# Altium schematic sheet advertising_board_v2.0.SchDoc
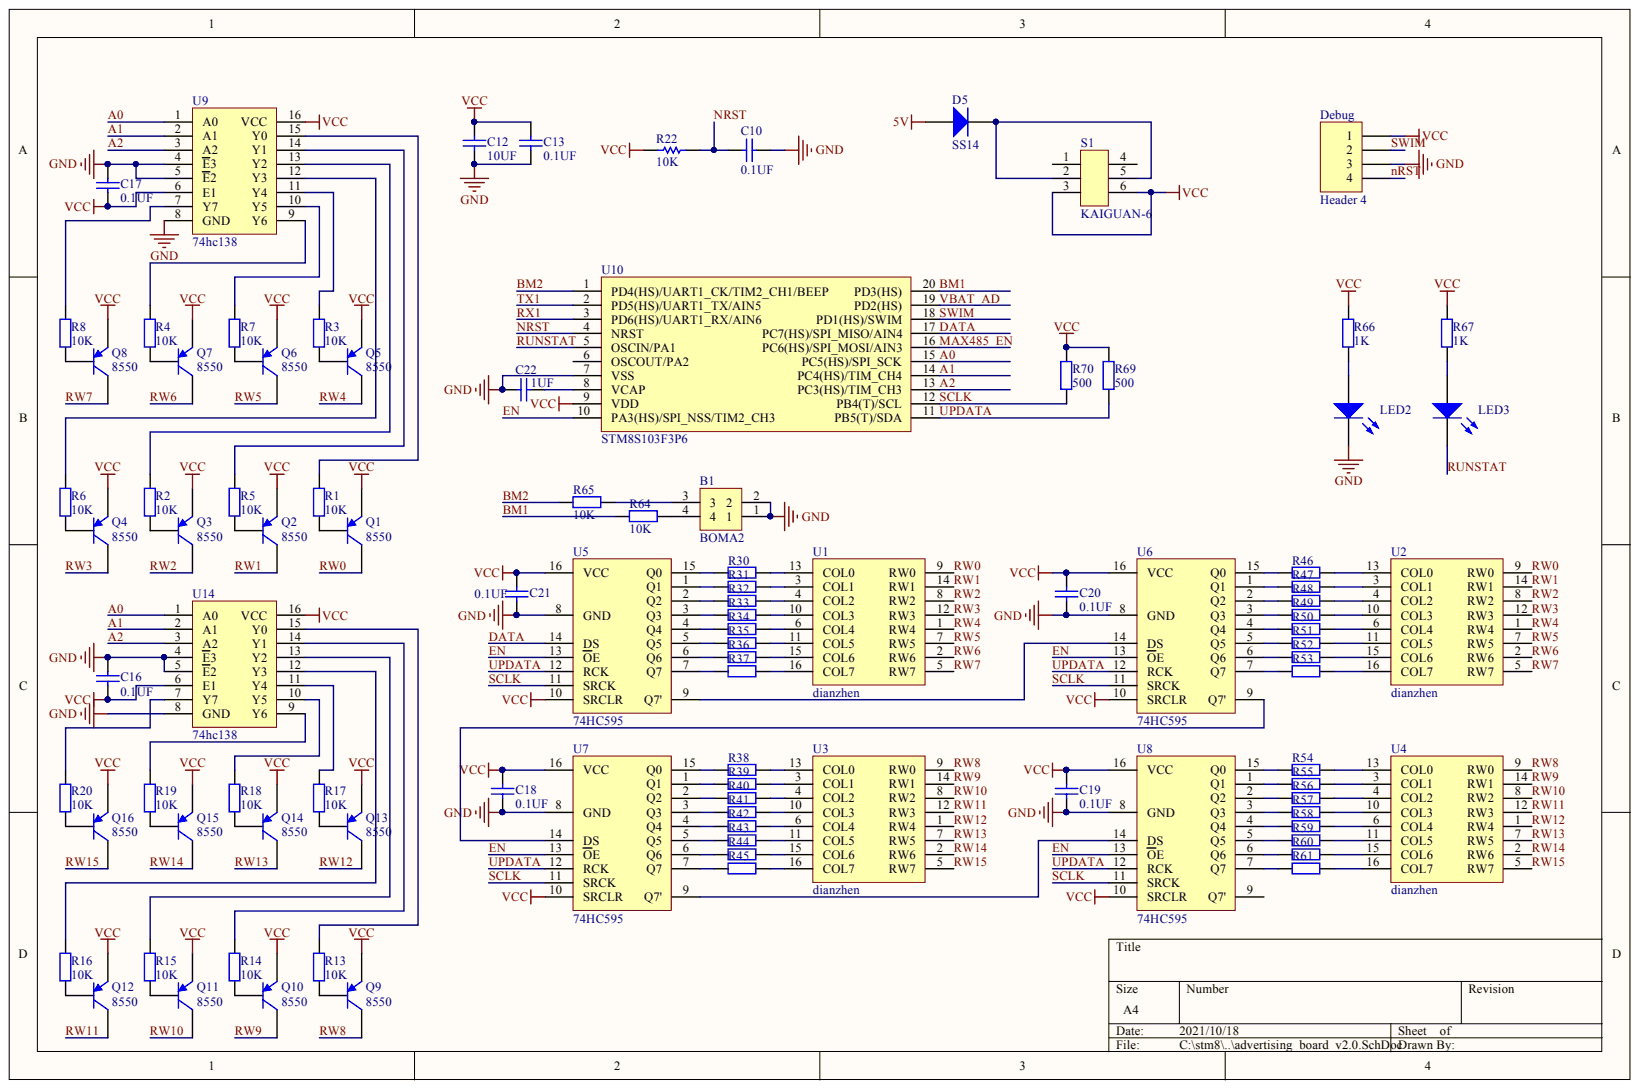
[diagram p0: advertising_board_v2.0.SchDoc]

## Parts
B1  BOMA2  [BOMA2]
C10  0.1UF  [0805c]
C12  10UF  [0805C]
C13  0.1UF  [0805C]
C16  0.1UF  [0805C]
C17  0.1UF  [0805C]
C18  0.1UF  [0805C]
C19  0.1UF  [0805C]
C20  0.1UF  [0805C]
C21  0.1UF  [0805C]
C22  1UF  [0805C]
D5  SS14  [SMA]
Debug  Header 4  [HDR1X4]
LED2  RED  [0805LED]  (value not drawn)
LED3  RED  [0805LED]  (value not drawn)
Q1  8550  [sot-89-d]
Q2  8550  [sot-89-d]
Q3  8550  [sot-89-d]
Q4  8550  [sot-89-d]
Q5  8550  [sot-89-d]
Q6  8550  [sot-89-d]
Q7  8550  [sot-89-d]
Q8  8550  [sot-89-d]
Q9  8550  [sot-89-d]
Q10  8550  [sot-89-d]
Q11  8550  [sot-89-d]
Q12  8550  [sot-89-d]
Q13  8550  [sot-89-d]
Q14  8550  [sot-89-d]
Q15  8550  [sot-89-d]
Q16  8550  [sot-89-d]
R1  10K  [0805]
R2  10K  [0805]
R3  10K  [0805]
R4  10K  [0805]
R5  10K  [0805]
R6  10K  [0805]
R7  10K  [0805]
R8  10K  [0805]
R13  10K  [0805]
R14  10K  [0805]
R15  10K  [0805]
R16  10K  [0805]
R17  10K  [0805]
R18  10K  [0805]
R19  10K  [0805]
R20  10K  [0805]
R22  10K  [0805]
R30  200  [0805]  (value not drawn)
R31  200  [0805]  (value not drawn)
R32  200  [0805]  (value not drawn)
R33  200  [0805]  (value not drawn)
R34  200  [0805]  (value not drawn)
R35  200  [0805]  (value not drawn)
R36  200  [0805]  (value not drawn)
R37  200  [0805]  (value not drawn)
R38  200  [0805]  (value not drawn)
R39  200  [0805]  (value not drawn)
R40  200  [0805]  (value not drawn)
R41  200  [0805]  (value not drawn)
R42  200  [0805]  (value not drawn)
R43  200  [0805]  (value not drawn)
R44  200  [0805]  (value not drawn)
R45  200  [0805]  (value not drawn)
R46  200  [0805]  (value not drawn)
R47  200  [0805]  (value not drawn)
R48  200  [0805]  (value not drawn)
R49  200  [0805]  (value not drawn)
R50  200  [0805]  (value not drawn)
R51  200  [0805]  (value not drawn)
R52  200  [0805]  (value not drawn)
R53  200  [0805]  (value not drawn)
R54  200  [0805]  (value not drawn)
R55  200  [0805]  (value not drawn)
R56  200  [0805]  (value not drawn)
R57  200  [0805]  (value not drawn)
R58  200  [0805]  (value not drawn)
R59  200  [0805]  (value not drawn)
R60  200  [0805]  (value not drawn)
R61  200  [0805]  (value not drawn)
R64  10K  [0805]
R65  10K  [0805]
R66  1K  [0805]
R67  1K  [0805]
R69  500  [0805]
R70  500  [0805]
S1  KAIGUAN-6  [KAIGUAN]
U1  dianzhen  [DIANZHEN-f3.75]
U2  dianzhen  [DIANZHEN-f3.75]
U3  dianzhen  [DIANZHEN-f3.75]
U4  dianzhen  [DIANZHEN-f3.75]
U5  74HC595  [SOP16]
U6  74HC595  [SOP16]
U7  74HC595  [SOP16]
U8  74HC595  [SOP16]
U9  74hc138  [SOP16]
U10  STM8S103F3P6  [TSSOP20_STM8]
U14  74hc138  [SOP16]

## Nets
5V: D5.1
GND: B1.1 B1.2 C10.2 C12.2 C13.2 C16.1 C17.1 C18.2 C19.2 C20.2 C21.2 C22.1 Debug.3 LED2.2 U5.8(GND) U6.8(GND) U7.8(GND) U8.8(GND) U9.4(E3) U9.5(E2) U9.8(GND) U10.7(VSS) U14.4(E3) U14.5(E2) U14.8(GND)
VCC: C12.1 C13.1 C16.2 C17.2 C18.1 C19.1 C20.1 C21.1 Debug.1 Q1.3 Q2.3 Q3.3 Q4.3 Q5.3 Q6.3 Q7.3 Q8.3 Q9.3 Q10.3 Q11.3 Q12.3 Q13.3 Q14.3 Q15.3 Q16.3 R22.2 R66.2 R67.2 R69.2 R70.2 S1.3 S1.6 U5.10(SRCLR) U5.16(VCC) U6.10(SRCLR) U6.16(VCC) U7.10(SRCLR) U7.16(VCC) U8.10(SRCLR) U8.16(VCC) U9.6(E1) U9.16(VCC) U10.9(VDD) U14.6(E1) U14.16(VCC)
A0: U9.1(A0) U10.15(PC5(HS)/SPI_SCK) U14.1(A0)
A1: U9.2(A1) U10.14(PC4(HS)/TIM_CH4) U14.2(A1)
A2: U9.3(A2) U10.13(PC3(HS)/TIM_CH3) U14.3(A2)
BM1: R64.1 U10.20(PD3(HS))
BM2: R65.1 U10.1(PD4(HS)/UART1_CK/TIM2_CH1/BEEP)
DATA: U5.14(DS) U10.17(PC7(HS)/SPI_MISO/AIN4)
EN: U5.13(OE) U6.13(OE) U7.13(OE) U8.13(OE) U10.10(PA3(HS)/SPI_NSS/TIM2_CH3)
MAX485_EN: U10.16(PC6(HS)/SPI_MOSI/AIN3)
NRST: C10.1 Debug.4 R22.1 U10.4(NRST)
RUNSTAT: LED3.2 U10.5(OSCIN/PA1)
RW0: Q1.2 U1.9(RW0) U2.9(RW0)
RW1: Q2.2 U1.14(RW1) U2.14(RW1)
RW2: Q3.2 U1.8(RW2) U2.8(RW2)
RW3: Q4.2 U1.12(RW3) U2.12(RW3)
RW4: Q5.2 U1.1(RW4) U2.1(RW4)
RW5: Q6.2 U1.7(RW5) U2.7(RW5)
RW6: Q7.2 U1.2(RW6) U2.2(RW6)
RW7: Q8.2 U1.5(RW7) U2.5(RW7)
RW8: Q9.2 U3.9(RW0) U4.9(RW0)
RW9: Q10.2 U3.14(RW1) U4.14(RW1)
RW10: Q11.2 U3.8(RW2) U4.8(RW2)
RW11: Q12.2 U3.12(RW3) U4.12(RW3)
RW12: Q13.2 U3.1(RW4) U4.1(RW4)
RW13: Q14.2 U3.7(RW5) U4.7(RW5)
RW14: Q15.2 U3.2(RW6) U4.2(RW6)
RW15: Q16.2 U3.5(RW7) U4.5(RW7)
RX1: U10.3(PD6(HS)/UART1_RX/AIN6)
SCLK: R70.1 U5.11(SRCK) U6.11(SRCK) U7.11(SRCK) U8.11(SRCK) U10.12(PB4(T)/SCL)
SWIM: Debug.2 U10.18(PD1(HS)/SWIM)
TX1: U10.2(PD5(HS)/UART1_TX/AIN5)
UPDATA: R69.1 U5.12(RCK) U6.12(RCK) U7.12(RCK) U8.12(RCK) U10.11(PB5(T)/SDA)
VBAT_AD: U10.19(PD2(HS))
NetB1_3: B1.3 R65.2
NetB1_4: B1.4 R64.2
NetC22_2: C22.2 U10.8(VCAP)
NetD5_2: D5.2 S1.2 S1.5
NetLED2_1: LED2.1 R66.1
NetLED3_1: LED3.1 R67.1
NetQ1_1: Q1.1 R1.1
NetQ2_1: Q2.1 R5.1
NetQ3_1: Q3.1 R2.1
NetQ4_1: Q4.1 R6.1
NetQ5_1: Q5.1 R3.2
NetQ6_1: Q6.1 R7.1
NetQ7_1: Q7.1 R4.1
NetQ8_1: Q8.1 R8.1
NetQ9_1: Q9.1 R13.2
NetQ10_1: Q10.1 R14.2
NetQ11_1: Q11.1 R15.1
NetQ12_1: Q12.1 R16.1
NetQ13_1: Q13.1 R17.1
NetQ14_1: Q14.1 R18.1
NetQ15_1: Q15.1 R19.1
NetQ16_1: Q16.1 R20.1
NetR1_2: R1.2 U9.15(Y0)
NetR2_2: R2.2 U9.13(Y2)
NetR3_1: R3.1 U9.11(Y4)
NetR4_2: R4.2 U9.9(Y6)
NetR5_2: R5.2 U9.14(Y1)
NetR6_2: R6.2 U9.12(Y3)
NetR7_2: R7.2 U9.10(Y5)
NetR8_2: R8.2 U9.7(Y7)
NetR13_1: R13.1 U14.15(Y0)
NetR14_1: R14.1 U14.14(Y1)
NetR15_2: R15.2 U14.13(Y2)
NetR16_2: R16.2 U14.12(Y3)
NetR17_2: R17.2 U14.11(Y4)
NetR18_2: R18.2 U14.10(Y5)
NetR19_2: R19.2 U14.9(Y6)
NetR20_2: R20.2 U14.7(Y7)
NetR30_1: R30.1 U5.15(Q0)
NetR30_2: R30.2 U1.13(COL0)
NetR31_1: R31.1 U5.1(Q1)
NetR31_2: R31.2 U1.3(COL1)
NetR32_1: R32.1 U5.2(Q2)
NetR32_2: R32.2 U1.4(COL2)
NetR33_1: R33.1 U5.3(Q3)
NetR33_2: R33.2 U1.10(COL3)
NetR34_1: R34.1 U5.4(Q4)
NetR34_2: R34.2 U1.6(COL4)
NetR35_1: R35.1 U5.5(Q5)
NetR35_2: R35.2 U1.11(COL5)
NetR36_1: R36.1 U5.6(Q6)
NetR36_2: R36.2 U1.15(COL6)
NetR37_1: R37.1 U5.7(Q7)
NetR37_2: R37.2 U1.16(COL7)
NetR38_1: R38.1 U7.15(Q0)
NetR38_2: R38.2 U3.13(COL0)
NetR39_1: R39.1 U7.1(Q1)
NetR39_2: R39.2 U3.3(COL1)
NetR40_1: R40.1 U7.2(Q2)
NetR40_2: R40.2 U3.4(COL2)
NetR41_1: R41.1 U7.3(Q3)
NetR41_2: R41.2 U3.10(COL3)
NetR42_1: R42.1 U7.4(Q4)
NetR42_2: R42.2 U3.6(COL4)
NetR43_1: R43.1 U7.5(Q5)
NetR43_2: R43.2 U3.11(COL5)
NetR44_1: R44.1 U7.6(Q6)
NetR44_2: R44.2 U3.15(COL6)
NetR45_1: R45.1 U7.7(Q7)
NetR45_2: R45.2 U3.16(COL7)
NetR46_1: R46.1 U6.15(Q0)
NetR46_2: R46.2 U2.13(COL0)
NetR47_1: R47.1 U6.1(Q1)
NetR47_2: R47.2 U2.3(COL1)
NetR48_1: R48.1 U6.2(Q2)
NetR48_2: R48.2 U2.4(COL2)
NetR49_1: R49.1 U6.3(Q3)
NetR49_2: R49.2 U2.10(COL3)
NetR50_1: R50.1 U6.4(Q4)
NetR50_2: R50.2 U2.6(COL4)
NetR51_1: R51.1 U6.5(Q5)
NetR51_2: R51.2 U2.11(COL5)
NetR52_1: R52.1 U6.6(Q6)
NetR52_2: R52.2 U2.15(COL6)
NetR53_1: R53.1 U6.7(Q7)
NetR53_2: R53.2 U2.16(COL7)
NetR54_1: R54.1 U8.15(Q0)
NetR54_2: R54.2 U4.13(COL0)
NetR55_1: R55.1 U8.1(Q1)
NetR55_2: R55.2 U4.3(COL1)
NetR56_1: R56.1 U8.2(Q2)
NetR56_2: R56.2 U4.4(COL2)
NetR57_1: R57.1 U8.3(Q3)
NetR57_2: R57.2 U4.10(COL3)
NetR58_1: R58.1 U8.4(Q4)
NetR58_2: R58.2 U4.6(COL4)
NetR59_1: R59.1 U8.5(Q5)
NetR59_2: R59.2 U4.11(COL5)
NetR60_1: R60.1 U8.6(Q6)
NetR60_2: R60.2 U4.15(COL6)
NetR61_1: R61.1 U8.7(Q7)
NetR61_2: R61.2 U4.16(COL7)
NetU5_9: U5.9(Q7') U6.14(DS)
NetU6_9: U6.9(Q7') U7.14(DS)
NetU7_9: U7.9(Q7') U8.14(DS)

Not connected: S1 1 4; U8 9(Q7'); U10 6(OSCOUT/PA2)

## Library data of the parts
C10 C12 C13 C16-C22 = Cap: Capacitor; Component Kind: Standard; Published: 8-Jun-2000; Publisher: Altium Limited
  C10: Revision: July-2002: Re-released for DXP Platform.; Value: 0.1UF
  C12: LatestRevisionDate: 17-Jul-2002; LatestRevisionNote: Re-released for DXP Platform.; Library Name: Miscellaneous Devices.IntLib; PackageReference: RAD-0.3; Signal Integrity: Cap; Simulation: CAP; Value: 10UF
  C13 C16-C21: LatestRevisionDate: 17-Jul-2002; LatestRevisionNote: Re-released for DXP Platform.; Library Name: Miscellaneous Devices.IntLib; PackageReference: RAD-0.3; Signal Integrity: Cap; Simulation: CAP; Value: 0.1UF
  C22: LatestRevisionDate: 17-Jul-2002; LatestRevisionNote: Re-released for DXP Platform.; Library Name: Miscellaneous Devices.IntLib; PackageReference: RAD-0.3; Signal Integrity: Cap; Simulation: CAP; Value: 1UF
D5 = Diode: Default Diode; Code_JEDEC: DO-214-AB; Component Kind: Standard; LatestRevisionDate: 17-Jul-2002; LatestRevisionNote: Re-released for DXP Platform.; Library Name: Miscellaneous Devices.IntLib; PackageReference: SMC; PackageVersion: Sep-1996; Published: 8-Jun-2000; Signal Integrity: Diode; Simulation: DIODE; Publisher: Altium Limited
Debug = Header 4: Header, 4-Pin; Component Kind: Standard; LatestRevisionDate: 17-Jul-2002; LatestRevisionNote: Re-released for DXP Platform.; Library Name: Miscellaneous Connectors.IntLib; Signal Integrity: Connector; Publisher: Altium Limited
LED2 LED3 = LED1: Typical RED GaAs LED; Component Kind: Standard; LatestRevisionDate: 08-Jul-2005; LatestRevisionNote: Mech Layer 1 removed.; Library Name: Miscellaneous Devices.IntLib; PackageReference: LED-1; Published: 8-Jun-2000; Simulation: LED1; Publisher: Altium Limited
R1-R8 R13-R20 R30-R61 R64-R67 R69 R70 = Res2: Resistor; Component Kind: Standard; Library Name: Miscellaneous Devices.IntLib; Published: 8-Jun-2000; Signal Integrity: Res; Simulation: RESISTOR; Publisher: Altium Limited
  R1-R8 R13-R20: LatestRevisionDate: 17-Jul-2002; LatestRevisionNote: Re-released for DXP Platform.; PackageReference: AXIAL-0.4; Value: 10K
  R30-R61: LatestRevisionDate: 17-Jul-2002; LatestRevisionNote: Re-released for DXP Platform.; PackageReference: AXIAL-0.4; Value: 200
  R64 R65: Revision: July-2002: Re-released for DXP Platform.; Value: 10K
  R66 R67: Revision: July-2002: Re-released for DXP Platform.; Value: 1K
  R69 R70: Revision: July-2002: Re-released for DXP Platform.; Value: 500
R22 = Res1: Resistor; Component Kind: Standard; Published: 8-Jun-2000; Revision: July-2002: Re-released for DXP Platform.; Value: 10K; Publisher: Altium Limited
U9 U14 = 74LS138: Component Kind: Standard; Library Name: IC.SchLib
B1 = BOMA2: Component Kind: Standard; Library Name: IC.SchLib
Q1-Q16 = 8550: Component Kind: Standard; Library Name: IC.SchLib
S1 = KAIGUAN-6: Component Kind: Standard; Library Name: IC.SchLib
U1-U4 = dianzhen: Component Kind: Standard; Library Name: IC.SchLib
U5-U8 = 74HC595: Component Kind: Standard; Library Name: IC.SchLib
U10 = STM8S103F3P6: Component Kind: Standard; Library Name: IC.SchLib
